# Altium schematic sheet 13_FEP&TF.SchDoc
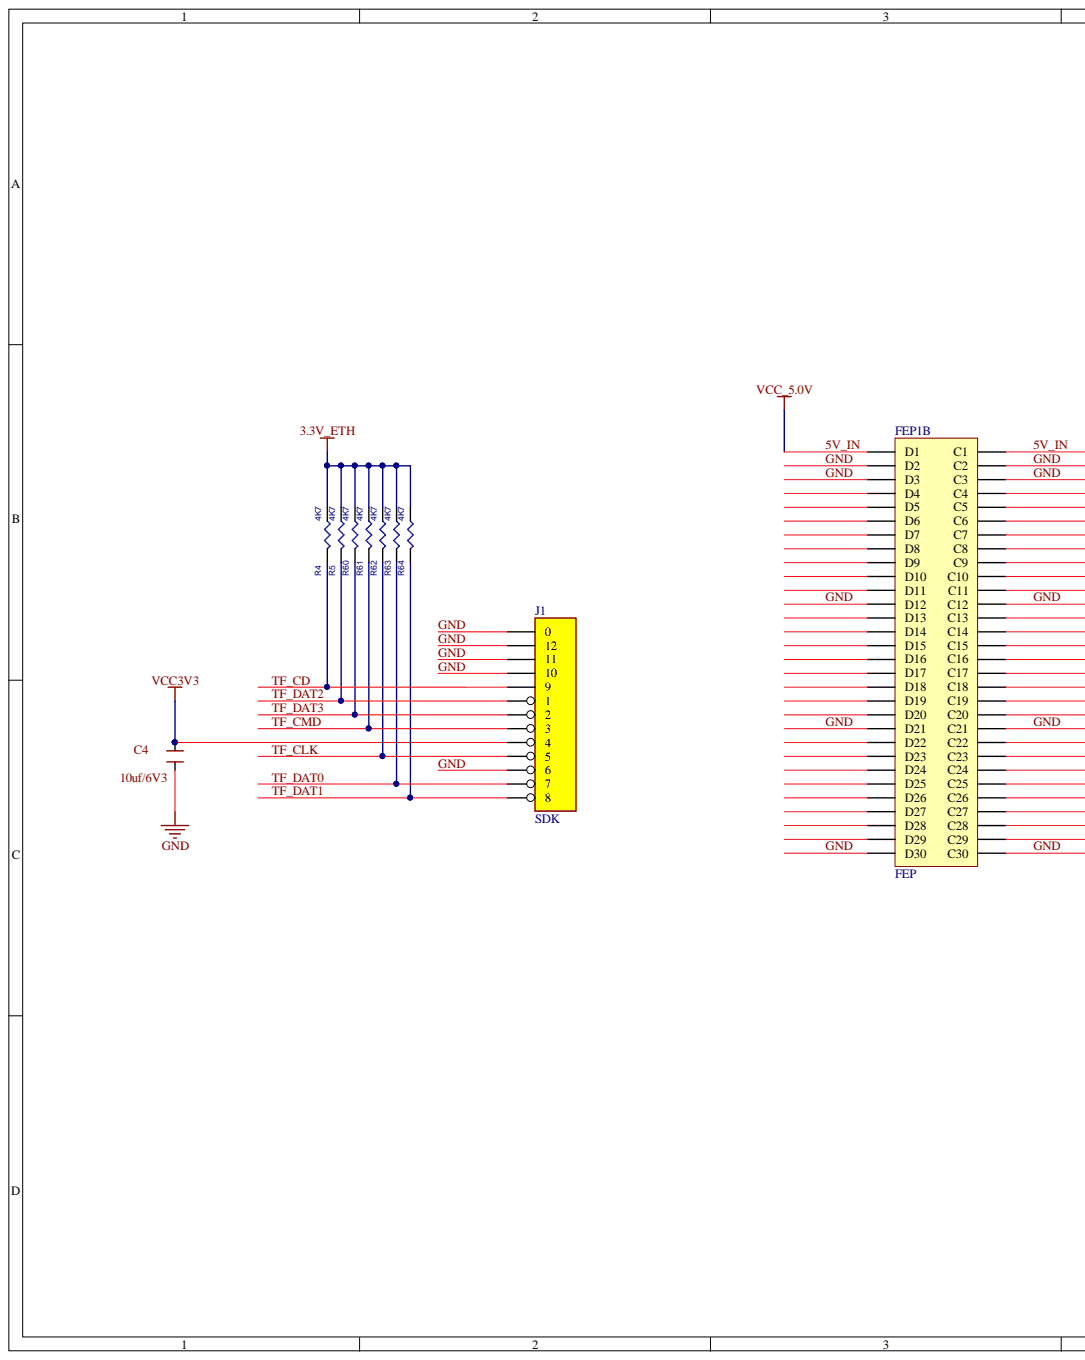
[diagram p0: 13_FEP&TF.SchDoc, left]
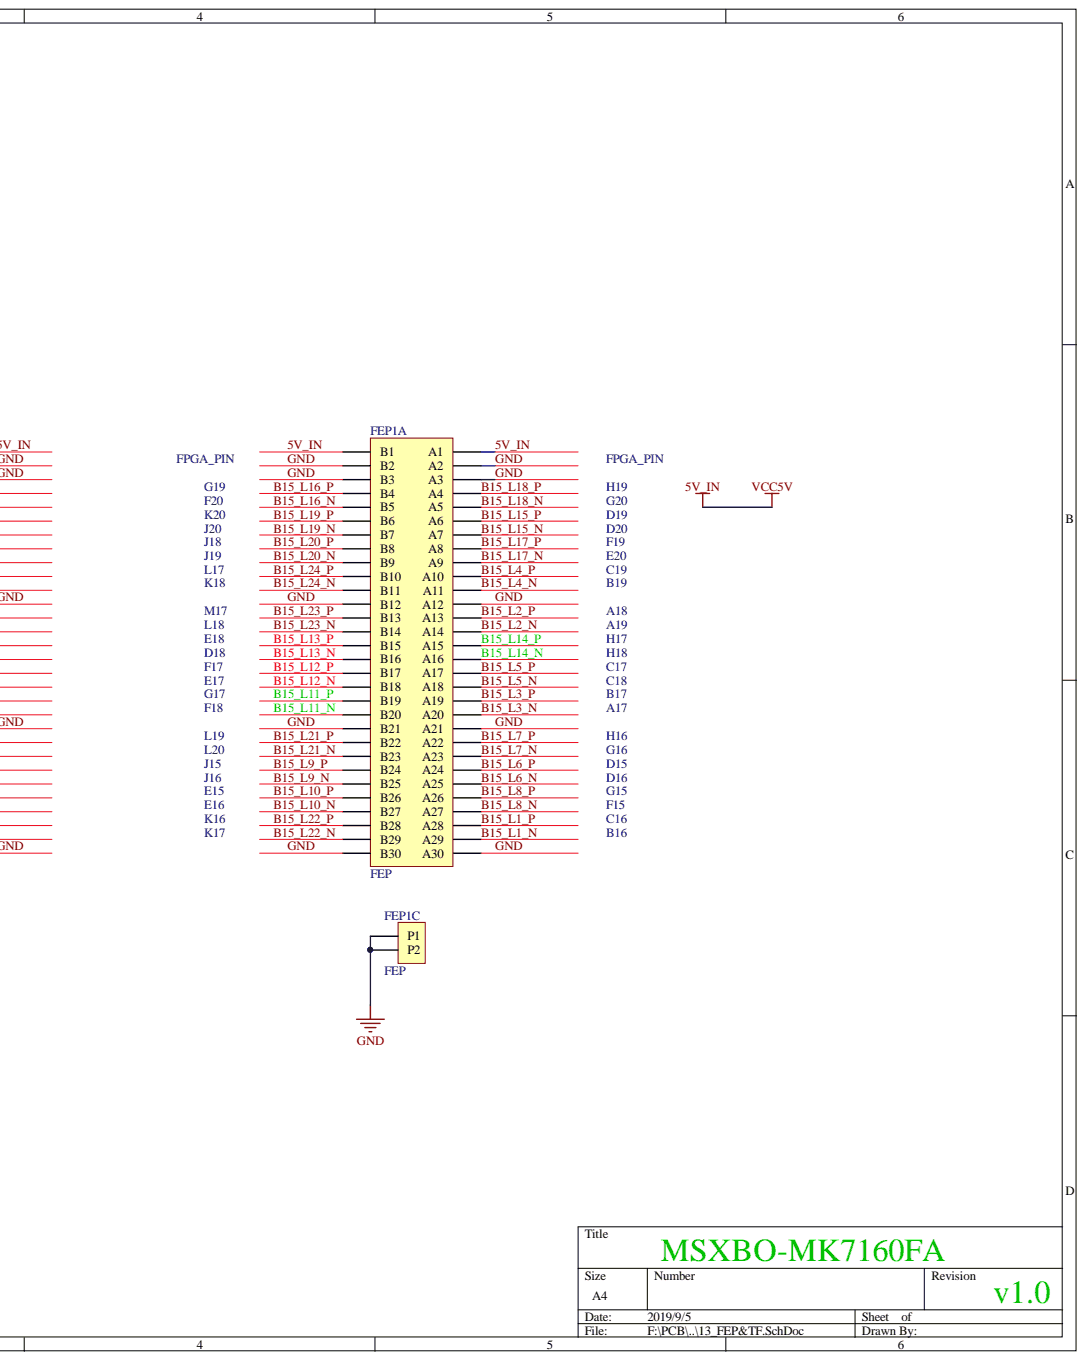
[diagram p1: 13_FEP&TF.SchDoc, right]

## Parts
C4  10uf/6V3  [CAP0603]
FEP1  FEP  [FEP-X2]  (parts A,B,C)
J1  SDK  [TF_K]
R4  4K7  [R0603]
R5  4K7  [R0603]
R60  4K7  [R0603]
R61  4K7  [R0603]
R62  4K7  [R0603]
R63  4K7  [R0603]
R64  4K7  [R0603]

## Nets
3.3V_ETH: R4.2 R5.2 R60.2 R61.2 R62.2 R63.2 R64.2
VCC3V3: C4.1 J1.4
5V_IN: FEP1.A1 FEP1.B1 FEP1.C1 FEP1.D1
B15_L1_N: FEP1.A29
B15_L1_P: FEP1.A28
B15_L2_N: FEP1.A14
B15_L2_P: FEP1.A13
B15_L3_N: FEP1.A20
B15_L3_P: FEP1.A19
B15_L4_N: FEP1.A11
B15_L4_P: FEP1.A10
B15_L5_N: FEP1.A18
B15_L5_P: FEP1.A17
B15_L6_N: FEP1.A25
B15_L6_P: FEP1.A24
B15_L7_N: FEP1.A23
B15_L7_P: FEP1.A22
B15_L8_N: FEP1.A27
B15_L8_P: FEP1.A26
B15_L9_N: FEP1.B25
B15_L9_P: FEP1.B24
B15_L10_N: FEP1.B27
B15_L10_P: FEP1.B26
B15_L11_N: FEP1.B20
B15_L11_P: FEP1.B19
B15_L12_N: FEP1.B18
B15_L12_P: FEP1.B17
B15_L13_N: FEP1.B16
B15_L13_P: FEP1.B15
B15_L14_N: FEP1.A16
B15_L14_P: FEP1.A15
B15_L15_N: FEP1.A7
B15_L15_P: FEP1.A6
B15_L16_N: FEP1.B5
B15_L16_P: FEP1.B4
B15_L17_N: FEP1.A9
B15_L17_P: FEP1.A8
B15_L18_N: FEP1.A5
B15_L18_P: FEP1.A4
B15_L19_N: FEP1.B7
B15_L19_P: FEP1.B6
B15_L20_N: FEP1.B9
B15_L20_P: FEP1.B8
B15_L21_N: FEP1.B23
B15_L21_P: FEP1.B22
B15_L22_N: FEP1.B29
B15_L22_P: FEP1.B28
B15_L23_N: FEP1.B14
B15_L23_P: FEP1.B13
B15_L24_N: FEP1.B11
B15_L24_P: FEP1.B10
GND: C4.2 FEP1.A2 FEP1.A3 FEP1.A12 FEP1.A21 FEP1.A30 FEP1.B2 FEP1.B3 FEP1.B12 FEP1.B21 FEP1.B30 FEP1.C2 FEP1.C3 FEP1.C12 FEP1.C21 FEP1.C30 FEP1.D2 FEP1.D3 FEP1.D12 FEP1.D21 FEP1.D30 FEP1.P1 FEP1.P2 J1.0 J1.6 J1.10 J1.11 J1.12
TF_CD: J1.9 R4.1
TF_CLK: J1.5 R62.1
TF_CMD: J1.3 R61.1
TF_DAT0: J1.7 R63.1
TF_DAT1: J1.8 R64.1
TF_DAT2: J1.1 R5.1
TF_DAT3: J1.2 R60.1
A17:
A18:
A19:
B16:
B17:
B19:
C16:
C17:
C18:
C19:
D15:
D16:
D18:
D19:
D20:
E15:
E16:
E17:
E18:
E20:
F15:
F17:
F18:
F19:
F20:
FPGA_PIN:
G15:
G16:
G17:
G19:
G20:
H16:
H17:
H18:
H19:
J15:
J16:
J18:
J19:
J20:
K16:
K17:
K18:
K20:
L17:
L18:
L19:
L20:
M17:

Not connected: FEP1 C4 C5 C6 C7 C8 C9 C10 C11 C13 C14 C15 C16 C17 C18 C19 C20 C22 C23 C24 C25 C26 C27 C28 C29 D4 D5 D6 D7 D8 D9 D10 D11 D13 D14 D15 D16 D17 D18 D19 D20 D22 D23 D24 D25 D26 D27 D28 D29

## Library data of the parts
C4 = CAPACITOR: Generic Capacitor; Value: 4.7uF; Component Kind: Standard; Library Name: Digilent.IntLib
FEP1 = FEP-2X: Component Kind: Standard; Library Name: MIZ7035_Fun.IntLib
J1 = SD: Component Kind: Standard; Library Name: jinrylib.IntLib
R4 R5 R60-R64 = RES1: DIST: FLAT; MAX_TEMP: RTMAX; POWER: RMAX; PSpiceTemplate: R^@REFDES %1 %2 ?TOLERANCE|R^@REFDES| @VALUE TC=@TC1,@TC2 ?TOLERANCE|\n.model R^@REFDES RES R=1 DEV=@TOLERANCE% TC1=@TC1 TC2=@TC2|; SLOPE: RSMAX; TC1: 0; VOLTAGE: RVMAX; Component Kind: Standard; Simulation: RES1; TC2: 0; Value: 4K7
